# Altium schematic sheet Display.SchDoc
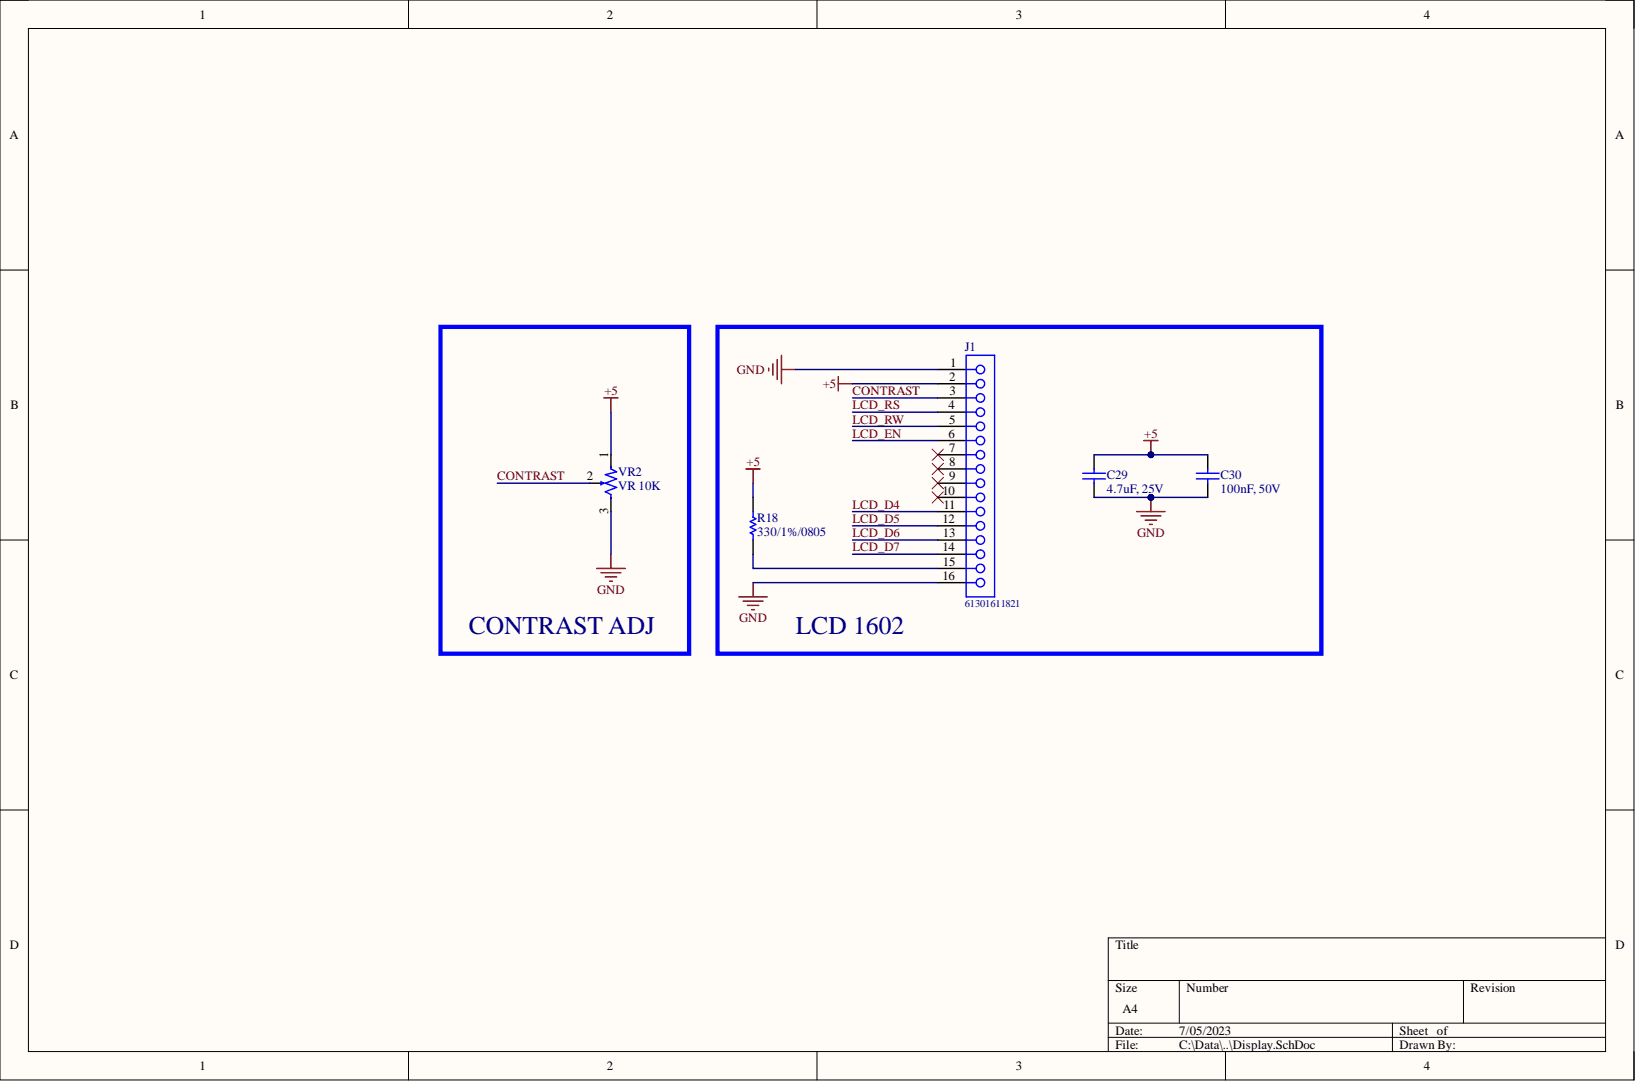
[diagram p0: Display.SchDoc]

## Parts
C29  4.7uF, 25V  [WCAP-CSGP_0805_H=1.25mm]
C30  100nF, 50V  [WCAP-CSGP_0805_H=0.8mm_Wave]
J1  61301611821  [61301611821]
R18  value 330/1%/0805  [RES-SM-0805]  (hidden comment: RESISTOR SMD)
VR2  10K  value VR 10K  [VARISTOR 2]

## Nets
+5: C29.2 C30.2 J1.2 R18.2 VR2.1
GND: C29.1 C30.1 J1.1 J1.16 VR2.3
CONTRAST: J1.3 VR2.2
LCD_D4: J1.11
LCD_D5: J1.12
LCD_D6: J1.13
LCD_D7: J1.14
LCD_EN: J1.6
LCD_RS: J1.4
LCD_RW: J1.5
NetJ1_15: J1.15 R18.1

Not connected: J1 7 8 9 10

## Library data of the parts
C29 = 885012107018: WCAP-CSGP Multilayer Ceramic Chip Capacitor, General Purpose, size 0805, X5R, 4.7uF, 25V; Manufacturer: Wurth Elektronik; Capacitance Tolerance: +/-20%; Dissipation Factor: #NAME?; Isolation Resistance: >=0.02GOhm; Rated Voltage: 25VDC; Technical Reference: X5R0805475M025DFCT10000; Capacitance: 4.7uF; Ceramic Type: X5R; Component Kind: Standard; ComponentLink1Description: Manufacturer; ComponentLink1URL: http://www.we-online.de; ComponentLink2Description: Datasheet; ComponentLink2URL: https://www.we-online.com/catalog/datasheet/885012107018.pdf; Height: 1.25mm; Library Name: Altium_WCAP-CSGP (rev20a).IntLib; Manufacturer Part Number: 885012107018; Mounting Technology: SMT; Operating Temperature: -55 to +85degC; Packaging: Tape and Reel; Published Date: May 2020; Size: 0805
C30 = 885012207098: WCAP-CSGP Multilayer Ceramic Chip Capacitor, General Purpose, size 0805, X7R, 100nF, 50V; Manufacturer: Wurth Elektronik; Capacitance Tolerance: +/-10%; Dissipation Factor: #NAME?; Isolation Resistance: >=5GOhm; Rated Voltage: 50VDC; Technical Reference: X7R0805104K050DFCT10000; Capacitance: 100nF; Ceramic Type: X7R; Component Kind: Standard; ComponentLink1Description: Manufacturer; ComponentLink1URL: http://www.we-online.de; ComponentLink2Description: Datasheet; ComponentLink2URL: https://www.we-online.com/catalog/datasheet/885012207098.pdf; Height: 0.8mm; Library Name: Altium_WCAP-CSGP (rev20a).IntLib; Manufacturer Part Number: 885012207098; Mounting Technology: SMT; Operating Temperature: -55 to +125degC; Packaging: Tape and Reel; Published Date: May 2020; Size: 0805
J1 = 61301611821: WR-PHD Socket Header, THT, pitch 2.54mm, Single Row, Vertical, 16p; Manufacturer: Wurth Elektronik; Contact Resistance: 20mOhm; Pin Number: 16; Rated Current: 3A; Withstanding Voltage: 500VAC; Working Voltage: 250VAC; Component Kind: Standard; ComponentLink1Description: Manufacturer; ComponentLink1URL: http://www.we-online.de; ComponentLink2Description: Datasheet; ComponentLink2URL: https://www.we-online.com/catalog/datasheet/61301611821.pdf; Gender: Socket Header; Library Name: Altium_WR-PHD (rev20a).IntLib; Manufacturer Part Number: 61301611821; Mounting Technology: THT; Operating Temperature: -40 to +125degC; Orientation: Vertical; Packaging: Tray; Pitch: 2.54mm; Published Date: May 2020; Row Number: Single
R18 = RESISTOR SMD: Resistor; Component Kind: Standard; Library Name: PIF_Library.IntLib
VR2 = Var Resistor: Component Kind: Standard; Description: Var Resistor; Library Name: PIF_Library.IntLib
